# Altium schematic sheet NanoRTC.SchDoc(NanoRTC)
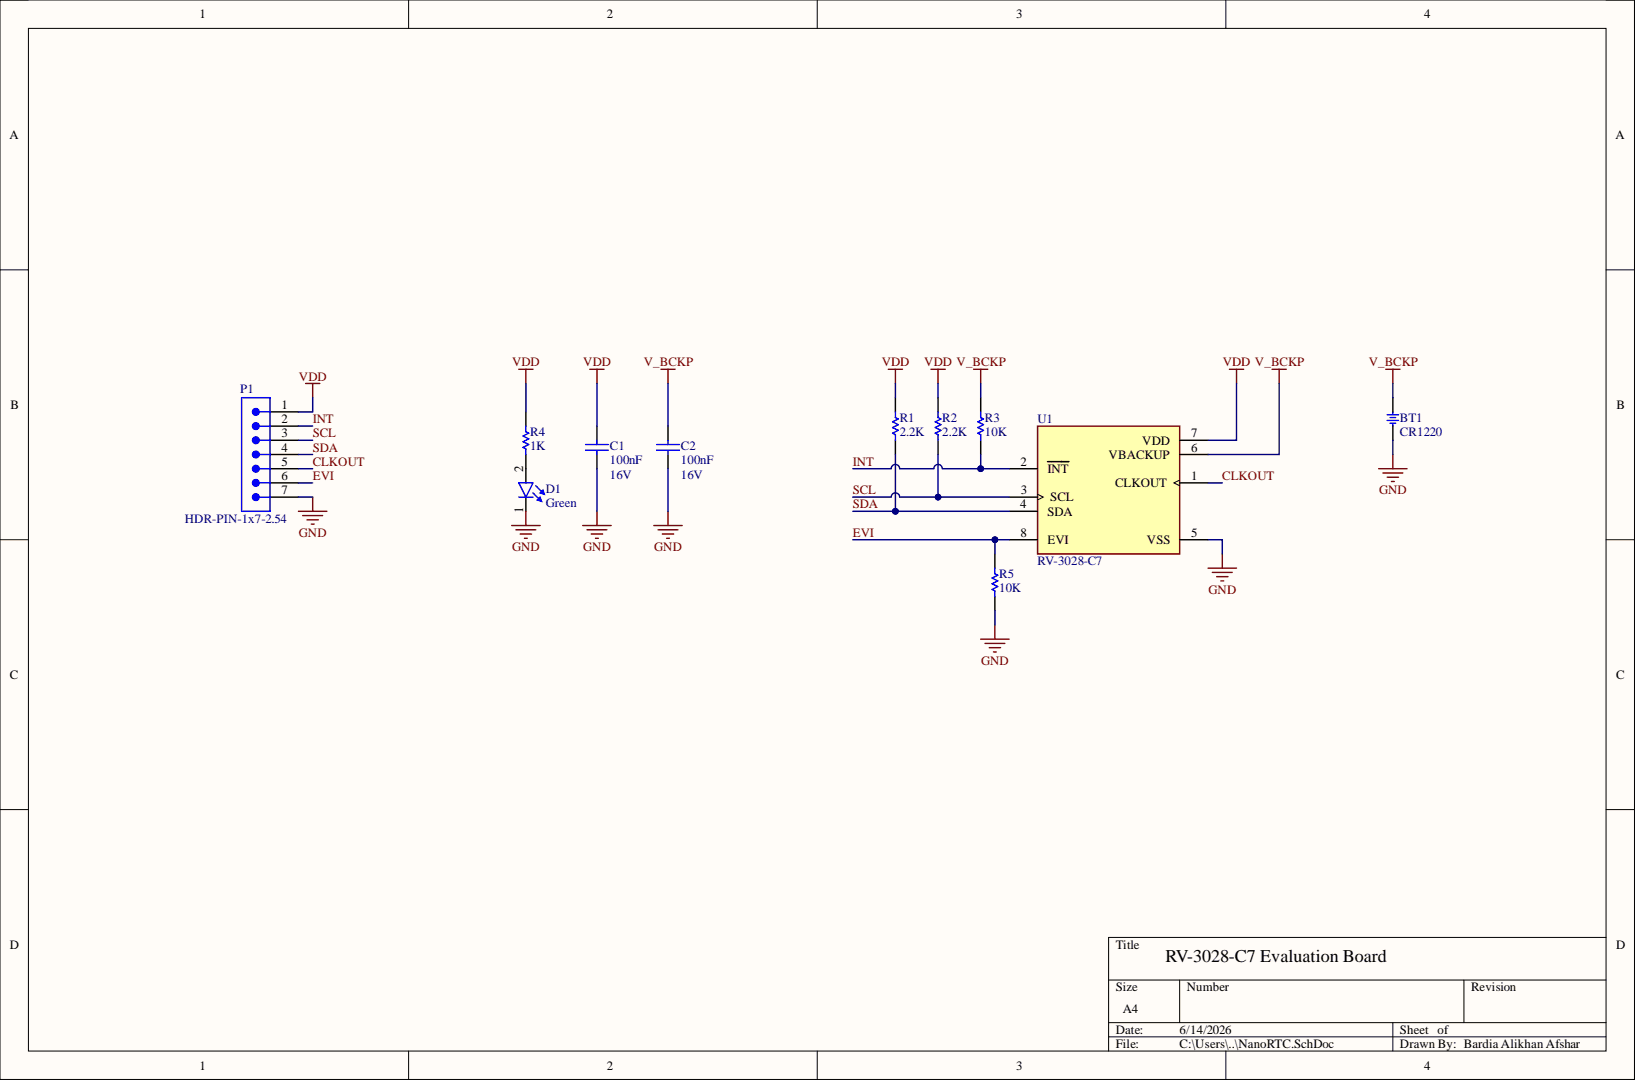
[diagram p0: NanoRTC.SchDoc]

## Parts
BT1  CR1220  [KH-CR1220-2]
C1  100nF  Voltage: 16V  [CAP_0402_IPC_MEDIUM_DENSITY]
C2  100nF  Voltage: 16V  [CAP_0402_IPC_MEDIUM_DENSITY]
D1  Green  [LED_0603_MEDIUM_DENSITY]
P1  HDR-PIN-1x7-2.54  [HDRV7W64P254_7X1_1778X250H854]
R1  2.2K  [RES_0402_IPC_MEDIUM_DENSITY]
R2  2.2K  [RES_0402_IPC_MEDIUM_DENSITY]
R3  10K  [RES_0402_IPC_MEDIUM_DENSITY]
R4  1K  [RES_0402_IPC_MEDIUM_DENSITY]
R5  10K  [RES_0402_IPC_MEDIUM_DENSITY]
U1  RV-3028-C7  [IC_RV-3028-C7]

## Nets
GND: BT1.2 C1.1 C2.1 D1.1 P1.7 R5.1 U1.5(VSS)
V_BCKP: BT1.1 C2.2 R3.2 U1.6(VBACKUP)
VDD: C1.2 P1.1 R1.2 R2.2 R4.2 U1.7(VDD)
CLKOUT: P1.5 U1.1(CLKOUT)
EVI: P1.6 R5.2 U1.8(EVI)
INT: P1.2 R3.1 U1.2(INT)
SCL: P1.3 R2.1 U1.3(SCL)
SDA: P1.4 R1.1 U1.4(SDA)
NetD1_2: D1.2 R4.1

## Library data of the parts
BT1 = KH-CR1220-2: Battery base CR1220 Through Hole Blade Type Power Connector Assemblies RoHS; Manufacturer: Shenzhen Kinghelm Elec; Designer: Bardia Alikhan Afshar; Component Kind: Standard; LCSC Part #: C5365933; Library Name: BatteryHolders.SchLib; Part Number: KH-CR1220-2; Value: CR1220
C1 C2 = CAP_0402: Capacitor, SMD 0402 Package, 1.0×0.5 mm Body; Part Number: CL05B104KO5NNNC; Designer: Bardia Alikhan Afshar; Component Kind: Standard; LCSC Part #: C1525; Library Name: Capacitors.SchLib; Value: 100nF
D1 = LED_0603: LED, SMD 0603 Package, 1.6×0.8 mm Body; Part Number: FC-1608G1-520; Designer: Bardia Alikhan Afshar; Component Kind: Standard; LCSC Part #: C19189745; Library Name: Display_Components.SchLib; Value: Green
P1 = HDR-PIN-1x7-2.54: 3A Through Hole Pin Header 2.54mm 6mm 7P -40℃~+105℃; Designer: Bardia Alikhan Afshar; Component Kind: Standard; Library Name: PinHeaders_Jumpers.SchLib
R1 R2 = RES_0402: Resistor, SMD 0402 Package, 1.0×0.5 mm Body; Manufacturer: Uniroyal Elec; Part Number: 0402WGF2201TCE; Designer: Bardia Alikhan Afshar; Component Kind: Standard; LCSC Part #: C25879; Library Name: Resistors.SchLib; Value: 2.2K
R3 R5 = RES_0402: Resistor, SMD 0402 Package, 1.0×0.5 mm Body; Manufacturer: Uniroyal Elec; Part Number: 0402WGF1002TCE; Designer: Bardia Alikhan Afshar; Component Kind: Standard; LCSC Part #: C25744; Library Name: Resistors.SchLib; Value: 10K
R4 = RES_0402: Resistor, SMD 0402 Package, 1.0×0.5 mm Body; Part Number: 0402WGF1001TCE; Designer: Bardia Alikhan Afshar; Component Kind: Standard; LCSC Part #: C11702; Library Name: Resistors.SchLib; Value: 1K
U1 = RV-3028-C7: Real-Time Clock Module in 1.5 x 3.2 mm package; Manufacturer: Micro Crystal; Designer: Bardia Alikhan Afshar; Component Kind: Standard; LCSC Part #: C3019759; Library Name: ICs.SchLib; Part Number: RV-3028-C7
